# Altium schematic sheet IND_EC.SchDoc
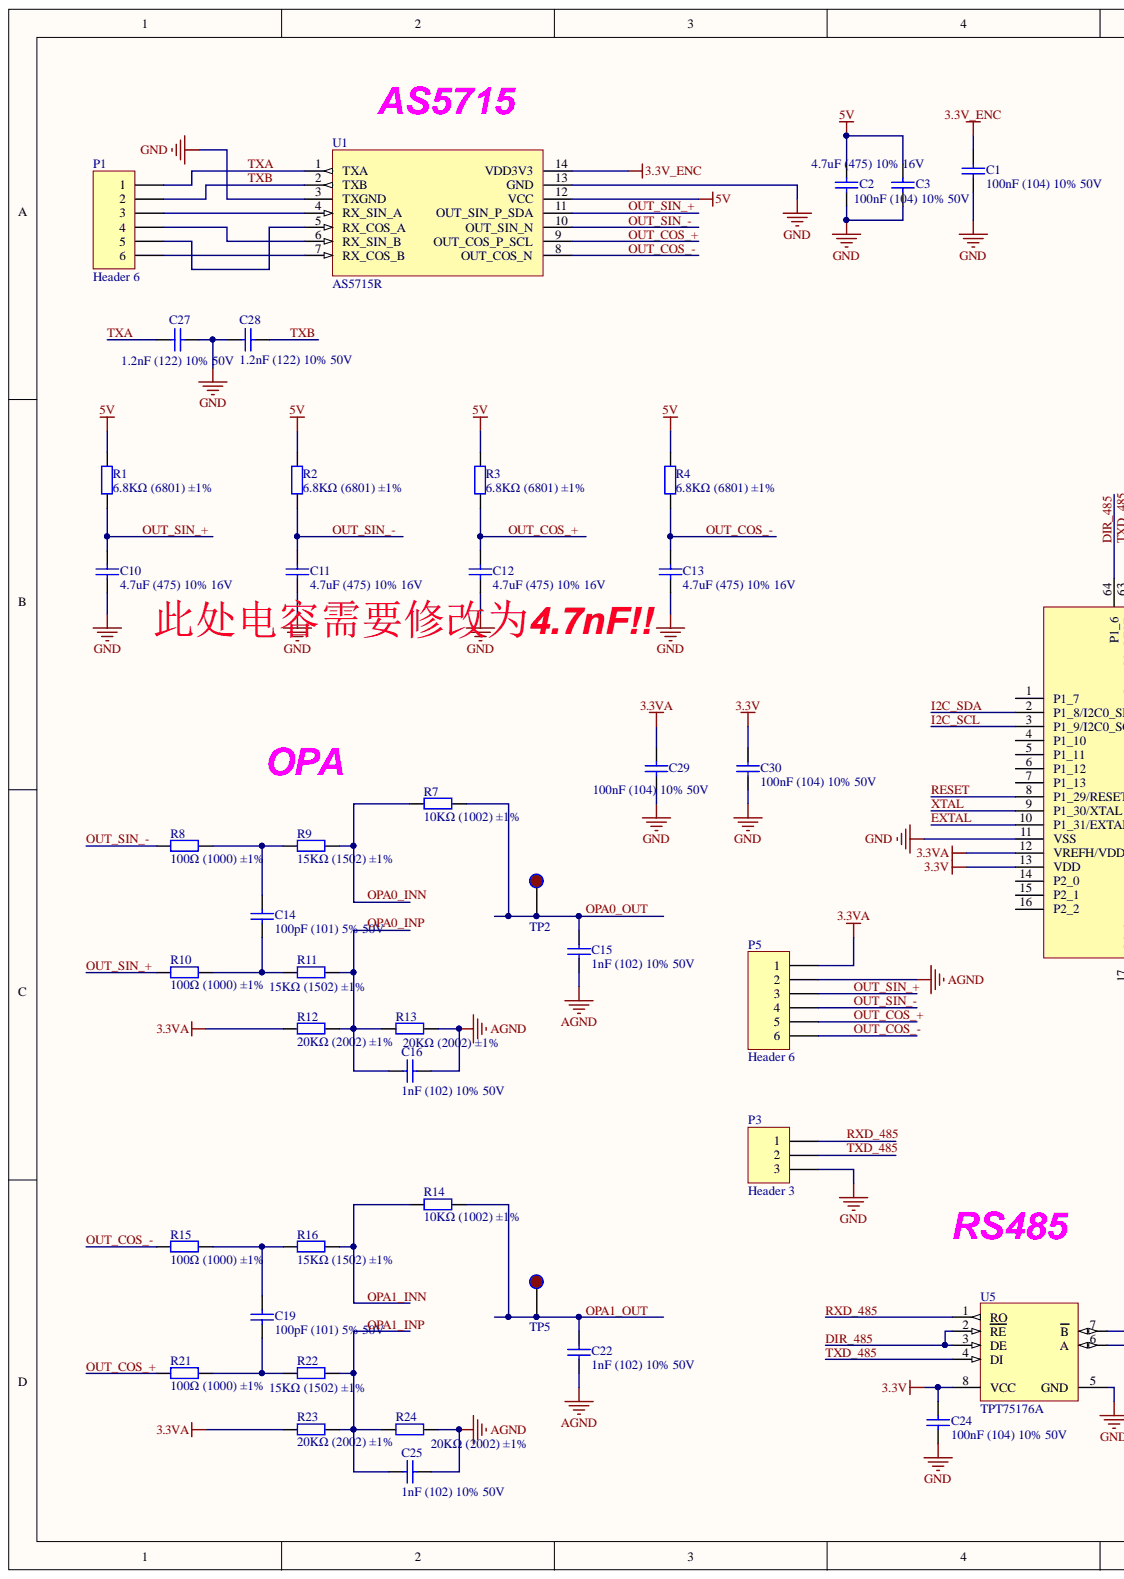
[diagram p0: IND_EC.SchDoc, left]
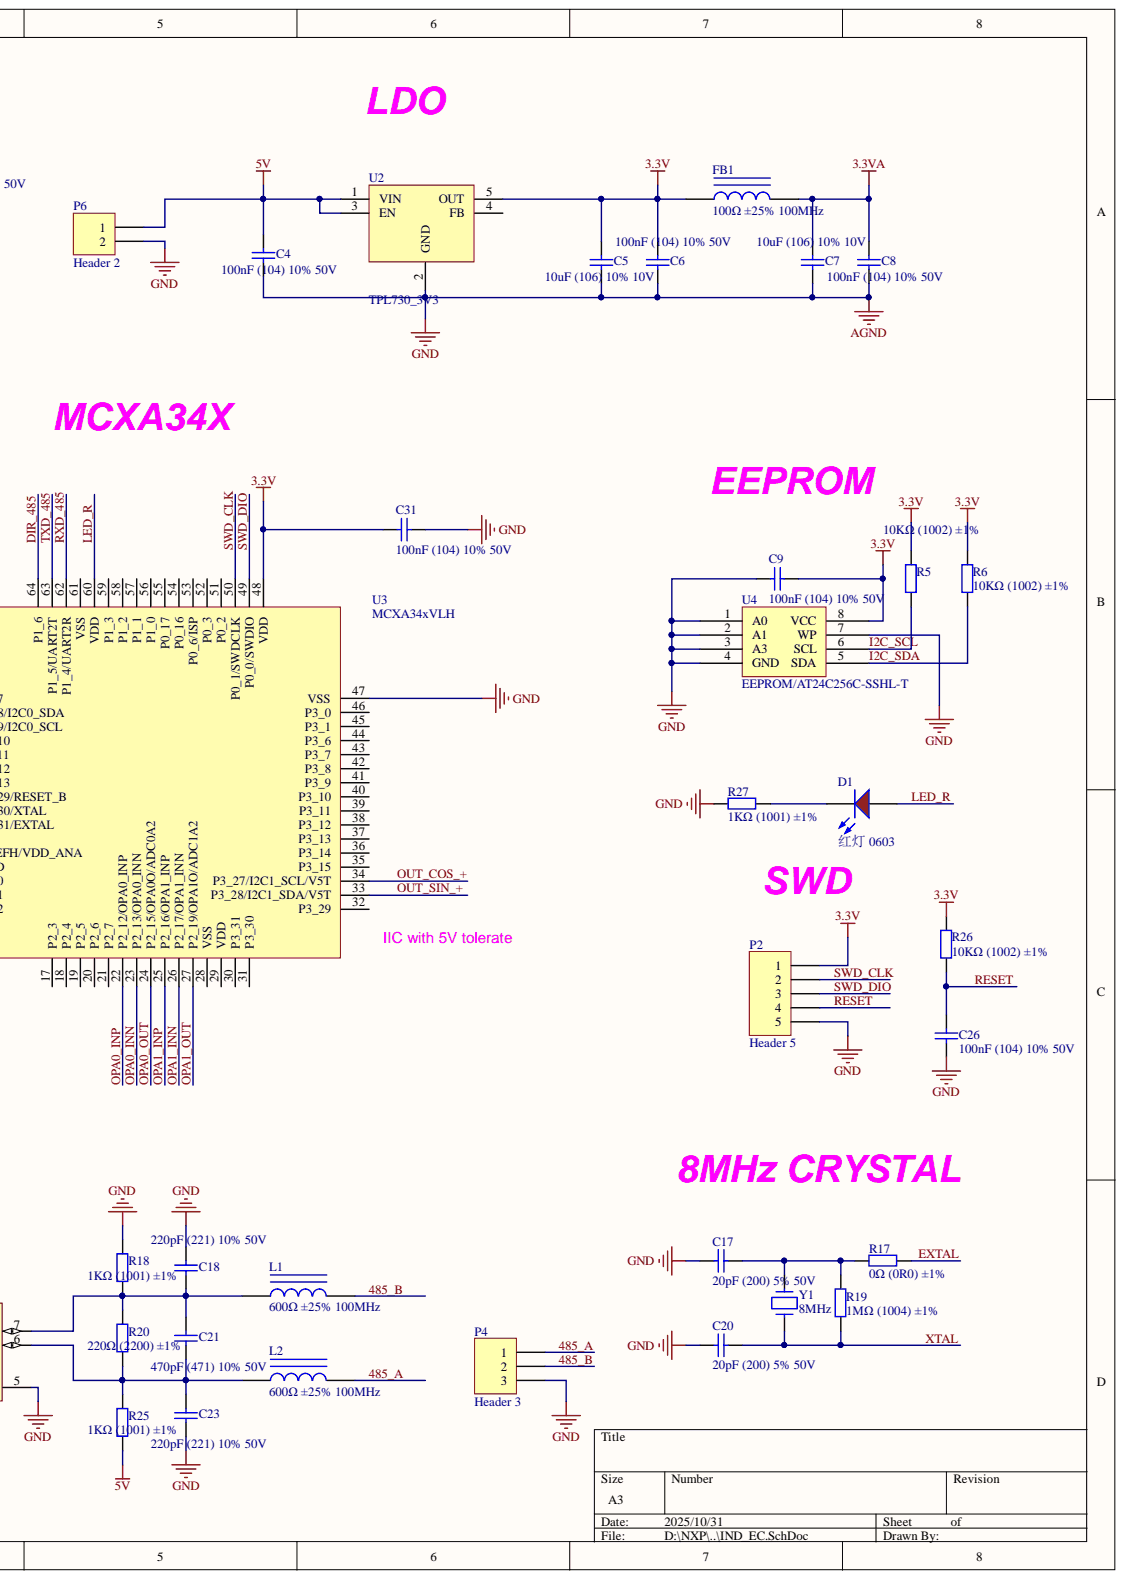
[diagram p1: IND_EC.SchDoc, right]

## Parts
C1  100nF (104) 10% 50V  [0603_C]
C2  4.7uF (475) 10% 16V  [0603_C]
C3  100nF (104) 10% 50V  [0603_C]
C4  100nF (104) 10% 50V  [0603_C]
C5  10uF (106) 10% 10V  [0603_C]
C6  100nF (104) 10% 50V  [0603_C]
C7  10uF (106) 10% 10V  [0603_C]
C8  100nF (104) 10% 50V  [0603_C]
C9  100nF (104) 10% 50V  [0603_C]
C10  4.7uF (475) 10% 16V  [0603_C]
C11  4.7uF (475) 10% 16V  [0603_C]
C12  4.7uF (475) 10% 16V  [0603_C]
C13  4.7uF (475) 10% 16V  [0603_C]
C14  100pF (101) 5% 50V  [0603_C]
C15  1nF (102) 10% 50V  [0603_C]
C16  1nF (102) 10% 50V  [0603_C]
C17  20pF (200) 5% 50V  [0603_C]
C18  220pF (221) 10% 50V  [0603_C]
C19  100pF (101) 5% 50V  [0603_C]
C20  20pF (200) 5% 50V  [0603_C]
C21  470pF (471) 10% 50V  [0603_C]
C22  1nF (102) 10% 50V  [0603_C]
C23  220pF (221) 10% 50V  [0603_C]
C24  100nF (104) 10% 50V  [0603_C]
C25  1nF (102) 10% 50V  [0603_C]
C26  100nF (104) 10% 50V  [0603_C]
C27  1.2nF (122) 10% 50V  [0603_C]
C28  1.2nF (122) 10% 50V  [0603_C]
C29  100nF (104) 10% 50V  [0603_C]
C30  100nF (104) 10% 50V  [0603_C]
C31  100nF (104) 10% 50V  [0603_C]
D1  红灯 0603  Package: 0603  [0603_LED_S1]
FB1  100Ω ±25% 100MHz  [0805_L]
L1  600Ω ±25% 100MHz  [0805_L]
L2  600Ω ±25% 100MHz  [0805_L]
P1  Header 6  [HDR1X6]
P2  Header 5  [XH2.54-5]
P3  Header 3  [HDR1X3]
P4  Header 3  [HDR1X3]
P5  Header 6  [HDR1X6]
P6  Header 2  [2EDG-3.5-2P-W]
R1  6.8KΩ (6801) ±1%  [0603_R]
R2  6.8KΩ (6801) ±1%  [0603_R]
R3  6.8KΩ (6801) ±1%  [0603_R]
R4  6.8KΩ (6801) ±1%  [0603_R]
R5  10KΩ (1002) ±1%  [0603_R]
R6  10KΩ (1002) ±1%  [0603_R]
R7  10KΩ (1002) ±1%  [0603_R]
R8  100Ω (1000) ±1%  [0603_R]
R9  15KΩ (1502) ±1%  [0603_R]
R10  100Ω (1000) ±1%  [0603_R]
R11  15KΩ (1502) ±1%  [0603_R]
R12  20KΩ (2002) ±1%  [0603_R]
R13  20KΩ (2002) ±1%  [0603_R]
R14  10KΩ (1002) ±1%  [0603_R]
R15  100Ω (1000) ±1%  [0603_R]
R16  15KΩ (1502) ±1%  [0603_R]
R17  0Ω (0R0) ±1%  [0603_R]
R18  1KΩ (1001) ±1%  [0603_R]
R19  1MΩ (1004) ±1%  [0603_R]
R20  220Ω (2200) ±1%  [0805_R]
R21  100Ω (1000) ±1%  [0603_R]
R22  15KΩ (1502) ±1%  [0603_R]
R23  20KΩ (2002) ±1%  [0603_R]
R24  20KΩ (2002) ±1%  [0603_R]
R25  1KΩ (1001) ±1%  [0603_R]
R26  10KΩ (1002) ±1%  [0603_R]
R27  1KΩ (1001) ±1%  [0603_R]
TP2  TP?  [TP]
TP5  TP?  [TP]
U1  AS5715R  [TSSOP-14]
U2  TPL730_3V3  [SOT-23-5]
U3  MCXA34xVLH  [LQFP-64_10x10x05P_Q2]
U4  EEPROM/AT24C256C-SSHL-T  [SOIC-8_150mil]
U5  TPT75176A  [SOIC-8_150mil]
Y1  8MHz  [SMD_5032_2P]

## Nets
3.3V: C5.2 C6.2 C9.1 C24.2 C30.1 C31.1 FB1.1 P2.1 R5.2 R6.2 R26.2 U2.5(OUT) U3.13(VDD) U3.48(VDD) U4.8(VCC) U5.8(VCC)
3.3V_ENC: C1.2 U1.14(VDD3V3)
3.3VA: C7.2 C8.2 C29.1 FB1.2 P5.1 R12.1 R23.1 U3.12(VREFH/VDD_ANA)
5V: C2.2 C3.2 C4.2 P6.1 R1.2 R2.2 R3.2 R4.2 R25.1 U1.12(VCC) U2.1(VIN) U2.3(EN)
AGND: C1.1 C2.1 C3.1 C4.1 C5.1 C6.1 C7.1 C8.1 C9.2 C10.1 C11.1 C12.1 C13.2 C15.1 C16.1 C17.2 C18.2 C20.2 C22.1 C23.1 C24.1 C25.1 C26.2 C27.2 C28.1 C29.2 C30.2 C31.2 P2.5 P3.3 P4.3 P5.2 P6.2 R13.2 R18.2 R24.2 R27.1 U1.3(TXGND) U1.13(GND) U2.2(GND) U3.11(VSS) U3.47(VSS) U4.1(A0) U4.2(A1) U4.3(A3) U4.4(GND) U4.7(WP) U5.5(GND)
485_A: L2.2 P4.1
485_B: L1.2 P4.2
DIR_485: U3.64(P1_6) U5.2(RE) U5.3(DE)
EXTAL: R17.1 U3.10(P1_31/EXTAL)
I2C_SCL: R5.1 U3.3(P1_9/I2C0_SCL) U4.6(SCL)
I2C_SDA: R6.1 U3.2(P1_8/I2C0_SDA) U4.5(SDA)
LED_R: D1.A U3.60(VDD)
OPA0_INN: R7.1 R9.2 U3.23(P2_13/OPA0_INN)
OPA0_INP: C16.2 R11.2 R12.2 R13.1 U3.22(P2_12/OPA0_INP)
OPA0_OUT: C15.2 R7.2 TP2.1 U3.24(P2_15/OPA0O/ADC0A2)
OPA1_INN: R14.1 R16.2 U3.26(P2_17/OPA1_INN)
OPA1_INP: C25.2 R22.2 R23.2 R24.1 U3.25(P2_16/OPA1_INP)
OPA1_OUT: C22.2 R14.2 TP5.1 U3.27(P2_19/OPA1O/ADC1A2)
OUT_COS_+: C12.2 P5.5 R3.1 R21.1 U1.9(OUT_COS_P_SCL) U3.34(P3_27/I2C1_SCL/V5T)
OUT_COS_-: C13.1 P5.6 R4.1 R15.1 U1.8(OUT_COS_N)
OUT_SIN_+: C10.2 P5.3 R1.1 R10.1 U1.11(OUT_SIN_P_SDA) U3.33(P3_28/I2C1_SDA/V5T)
OUT_SIN_-: C11.2 P5.4 R2.1 R8.1 U1.10(OUT_SIN_N)
RESET: C26.1 P2.4 R26.1 U3.8(P1_29/RESET_B)
RXD_485: P3.1 U3.62(P1_4/UART2R) U5.1(RO)
SWD_CLK: P2.2 U3.50(P0_1/SWDCLK)
SWD_DIO: P2.3 U3.49(P0_0/SWDIO)
TXA: C27.1 P1.1 U1.1(TXA)
TXB: C28.2 P1.2 U1.2(TXB)
TXD_485: P3.2 U3.63(P1_5/UART2T) U5.4(DI)
XTAL: C20.1 R19.1 U3.9(P1_30/XTAL) Y1.1
NetC14_1: C14.1 R10.2 R11.1
NetC14_2: C14.2 R8.2 R9.1
NetC17_1: C17.1 R17.2 R19.2 Y1.2
NetC18_1: C18.1 C21.2 L1.1 R18.1 R20.2 U5.7(B)
NetC19_1: C19.1 R21.2 R22.1
NetC19_2: C19.2 R15.2 R16.1
NetC21_1: C21.1 C23.2 L2.1 R20.1 R25.2 U5.6(A)
NetD1_K: D1.K R27.2
NetP1_3: P1.3 U1.4(RX_SIN_A)
NetP1_4: P1.4 U1.6(RX_SIN_B)
NetP1_5: P1.5 U1.5(RX_COS_A)
NetP1_6: P1.6 U1.7(RX_COS_B)

Not connected: U2 4(FB); U3 1(P1_7) 4(P1_10) 5(P1_11) 6(P1_12) 7(P1_13) 14(P2_0) 15(P2_1) 16(P2_2) 17(P2_3) 18(P2_4) 19(P2_5) 20(P2_6) 21(P2_7) 28(VSS) 29(VDD) 30(P3_31) 31(P3_30) 32(P3_29) 35(P3_15) 36(P3_14) 37(P3_13) 38(P3_12) 39(P3_11) 40(P3_10) 41(P3_9) 42(P3_8) 43(P3_7) 44(P3_6) 45(P3_1) 46(P3_0) 51(P0_2) 52(P0_3) 53(P0_6/ISP) 54(P0_16) 55(P0_17) 56(P1_0) 57(P1_1) 58(P1_2) 59(P1_3) 61(VSS)

## Library data of the parts
C1 C3 C4 C6 C8 C9 C24 = 0603 100nF (104) 10% 50V: 贴片电容; Component Kind: Standard; ComponentLink1Description: 供应商链接; ComponentLink1URL: http://www.szlcsc.com/product/details_15331.html; Library Name: Cap.SchLib; Package: 0603; Supplier: LC; SuppliersPartNumber: C14663
C2 C10-C13 = 0603 4.7uF (475) 10% 16V: 贴片电容; Component Kind: Standard; ComponentLink1Description: 供应商链接; ComponentLink1URL: http://www.szlcsc.com/product/details_20375.html; Library Name: Cap.SchLib; Package: 0603; Supplier: LC; SuppliersPartNumber: C19666
C5 C7 = 0603 10uF (106) 10% 10V: 贴片电容; Component Kind: Standard; ComponentLink1Description: 供应商链接; ComponentLink1URL: http://www.szlcsc.com/product/details_20411.html; Library Name: Cap.SchLib; Package: 0603; Supplier: LC; SuppliersPartNumber: C19702
C14 C19 = 0603 100pF (101) 5% 50V: 贴片电容; Component Kind: Standard; ComponentLink1Description: 供应商链接; ComponentLink1URL: http://www.szlcsc.com/product/details_15530.html; Library Name: Cap.SchLib; Package: 0603; Supplier: LC; SuppliersPartNumber: C14858
C15 C16 C22 C25 = 0603 1nF (102) 10% 50V: 贴片电容; Component Kind: Standard; ComponentLink1Description: 供应商链接; ComponentLink1URL: http://www.szlcsc.com/product/details_1940.html; Library Name: Cap.SchLib; Package: 0603; Supplier: LC; SuppliersPartNumber: C1588
C17 C20 = 0603 20pF (200) 5% 50V: 贴片电容; Component Kind: Standard; ComponentLink1Description: 供应商链接; ComponentLink1URL: http://www.szlcsc.com/product/details_2000.html; Library Name: Cap.SchLib; Package: 0603; Supplier: LC; SuppliersPartNumber: C1648
C18 C23 = 0603 220pF (221) 10% 50V: 贴片电容; Component Kind: Standard; ComponentLink1Description: 供应商链接; ComponentLink1URL: http://www.szlcsc.com/product/details_1955.html; Library Name: Cap.SchLib; Package: 0603; Supplier: LC; SuppliersPartNumber: C1603
C21 = 0603 470pF (471) 10% 50V: 贴片电容; Component Kind: Standard; ComponentLink1Description: 供应商链接; ComponentLink1URL: http://www.szlcsc.com/product/details_1972.html; Library Name: Cap.SchLib; Package: 0603; Supplier: LC; SuppliersPartNumber: C1620
C26 C29-C31 = 0603 100nF (104) 10%: 贴片电容; Component Kind: Standard; ComponentLink1Description: 供应商链接; ComponentLink1URL: http://www.szlcsc.com/product/details_15331.html; Library Name: Cap.SchLib; Package: 0603; Supplier: LC; SuppliersPartNumber: C14663
C27 C28 = 0603 1.2nF (122) 10% 50V: 贴片电容; Component Kind: Standard; ComponentLink1Description: 供应商链接; ComponentLink1URL: http://www.szlcsc.com/product/details_31372.html; Library Name: Cap.SchLib; Package: 0603; Supplier: LC; SuppliersPartNumber: C30615
D1 = 0603 红灯: 发光二极管; Component Kind: Standard; ComponentLink1Description: 供应商链接; ComponentLink1URL: http://www.szlcsc.com/product/details_2659.html; Library Name: LED.SchLib; Supplier: LC; SuppliersPartNumber: C2286
FB1 = 0805 100Ω 25% 100MHz: 贴片磁珠; Component Kind: Standard; ComponentLink1Description: 供应商链接; ComponentLink1URL: http://www.szlcsc.com/product/details_1367.html; Library Name: IUD.SchLib; Package: 0805; Supplier: LC; SuppliersPartNumber: C1015
L1 L2 = 0805 600Ω 25% 100MHz: 贴片磁珠; Component Kind: Standard; ComponentLink1Description: 供应商链接; ComponentLink1URL: http://www.szlcsc.com/product/details_1369.html; Library Name: IUD.SchLib; Package: 0805; Supplier: LC; SuppliersPartNumber: C1017
P1 P5 = Header 6: Header, 6-Pin; Component Kind: Standard; LatestRevisionDate: 17-Jul-2002; LatestRevisionNote: Re-released for DXP Platform.; Library Name: Miscellaneous Connectors.IntLib; Signal Integrity: Connector; Publisher: Altium Limited
P2 = Header 5: Header, 5-Pin; Component Kind: Standard; LatestRevisionDate: 17-Jul-2002; LatestRevisionNote: Re-released for DXP Platform.; Library Name: Miscellaneous Connectors.IntLib; Signal Integrity: Connector; Publisher: Altium Limited
P3 P4 = Header 3: Header, 3-Pin; Component Kind: Standard; LatestRevisionDate: 17-Jul-2002; LatestRevisionNote: Re-released for DXP Platform.; Library Name: Miscellaneous Connectors.IntLib; Signal Integrity: Connector; Publisher: Altium Limited
P6 = Header 2: Header, 2-Pin; Component Kind: Standard; LatestRevisionDate: 17-Jul-2002; LatestRevisionNote: Re-released for DXP Platform.; Library Name: Miscellaneous Connectors.IntLib; Signal Integrity: Connector; Publisher: Altium Limited
R1-R4 = 0603 6.8KΩ (6801) 1%: 贴片电阻; Component Kind: Standard; ComponentLink1Description: 供应商链接; ComponentLink1URL: http://www.szlcsc.com/product/details_23939.html; Library Name: RES.SchLib; Package: 0603; Supplier: LC; SuppliersPartNumber: C23212
R5-R7 R14 = 0603 10KΩ (1002) 1%: 贴片电阻; Component Kind: Standard; ComponentLink1Description: 供应商链接; ComponentLink1URL: http://www.szlcsc.com/product/details_26547.html; Library Name: RES.SchLib; Package: 0603; Supplier: LC; SuppliersPartNumber: C25804
R8 R10 R15 R21 = 0603 100Ω (1000) 1%: 贴片电阻; Component Kind: Standard; ComponentLink1Description: 供应商链接; ComponentLink1URL: http://www.szlcsc.com/product/details_23502.html; Library Name: RES.SchLib; Package: 0603; Supplier: LC; SuppliersPartNumber: C22775
R9 R11 R16 R22 = 0603 15KΩ (1502) 1%: 贴片电阻; Component Kind: Standard; ComponentLink1Description: 供应商链接; ComponentLink1URL: http://www.szlcsc.com/product/details_23536.html; Library Name: RES.SchLib; Package: 0603; Supplier: LC; SuppliersPartNumber: C22809
R12 R13 R23 R24 = 0603 20KΩ (2002) 1%: 贴片电阻; Component Kind: Standard; ComponentLink1Description: 供应商链接; ComponentLink1URL: http://www.szlcsc.com/product/details_4591.html; Library Name: RES.SchLib; Package: 0603; Supplier: LC; SuppliersPartNumber: C4184
R17 = 0603 0Ω (0R0) 1%: 贴片电阻; Component Kind: Standard; ComponentLink1Description: 供应商链接; ComponentLink1URL: http://www.szlcsc.com/product/details_21903.html; Library Name: RES.SchLib; Package: 0603; Supplier: LC; SuppliersPartNumber: C21189
R18 R25 R27 = 0603 1KΩ (1001) 1%: 贴片电阻; Component Kind: Standard; ComponentLink1Description: 供应商链接; ComponentLink1URL: http://www.szlcsc.com/product/details_21904.html; Library Name: RES.SchLib; Package: 0603; Supplier: LC; SuppliersPartNumber: C21190
R19 = 0603 1MΩ (1004) 1%: 贴片电阻; Component Kind: Standard; ComponentLink1Description: 供应商链接; ComponentLink1URL: http://www.szlcsc.com/product/details_23662.html; Library Name: RES.SchLib; Package: 0603; Supplier: LC; SuppliersPartNumber: C22935
R20 = 0805 220Ω (2200) 1%: 贴片电阻; Component Kind: Standard; ComponentLink1Description: 供应商链接; ComponentLink1URL: http://www.szlcsc.com/product/details_18245.html; Library Name: RES.SchLib; Package: 0805; Supplier: LC; SuppliersPartNumber: C17557
R26 = 0603 10KΩ (100: 贴片电阻; Component Kind: Standard; ComponentLink1Description: 供应商链接; ComponentLink1URL: http://www.szlcsc.com/product/details_26547.html; Library Name: RES.SchLib; Package: 0603; Supplier: LC; SuppliersPartNumber: C25804
TP2 TP5 = Test Point: Component Kind: Standard; Library Name: MyLib.SCHLIB
U1 = AS5715R: AMS; Component Kind: Standard; Library Name: MyLib.SCHLIB
U2 = TPL730: Component Kind: Standard; Library Name: MyLib.SCHLIB
U4 = EEPROM/AT24C256C-SSHL-T: EEPROM; Component Kind: Standard; ComponentLink1Description: 供应商链接; ComponentLink1URL: http://www.szlcsc.com/product/details_6947.html; Library Name: IC.SchLib; Package: SOIC-8_150mil; Supplier: LC; SuppliersPartNumber: C6482
U5 = SP485EEN-L/TR: RS-485/RS-422; Component Kind: Standard; ComponentLink1Description: 供应商链接; ComponentLink1URL: http://www.szlcsc.com/product/details_7327.html; Library Name: LC IC.SchLib; Package: SOIC-8; Supplier: LC; SuppliersPartNumber: C6855
Y1 = Crystal: 晶振; Component Kind: Standard; Library Name: MyLib.SCHLIB
U3 = MCXA34xVLH: Component Kind: Standard; Library Name: MyLib.SCHLIB
